# Altium schematic sheet MAIN.SchDoc(MAIN)
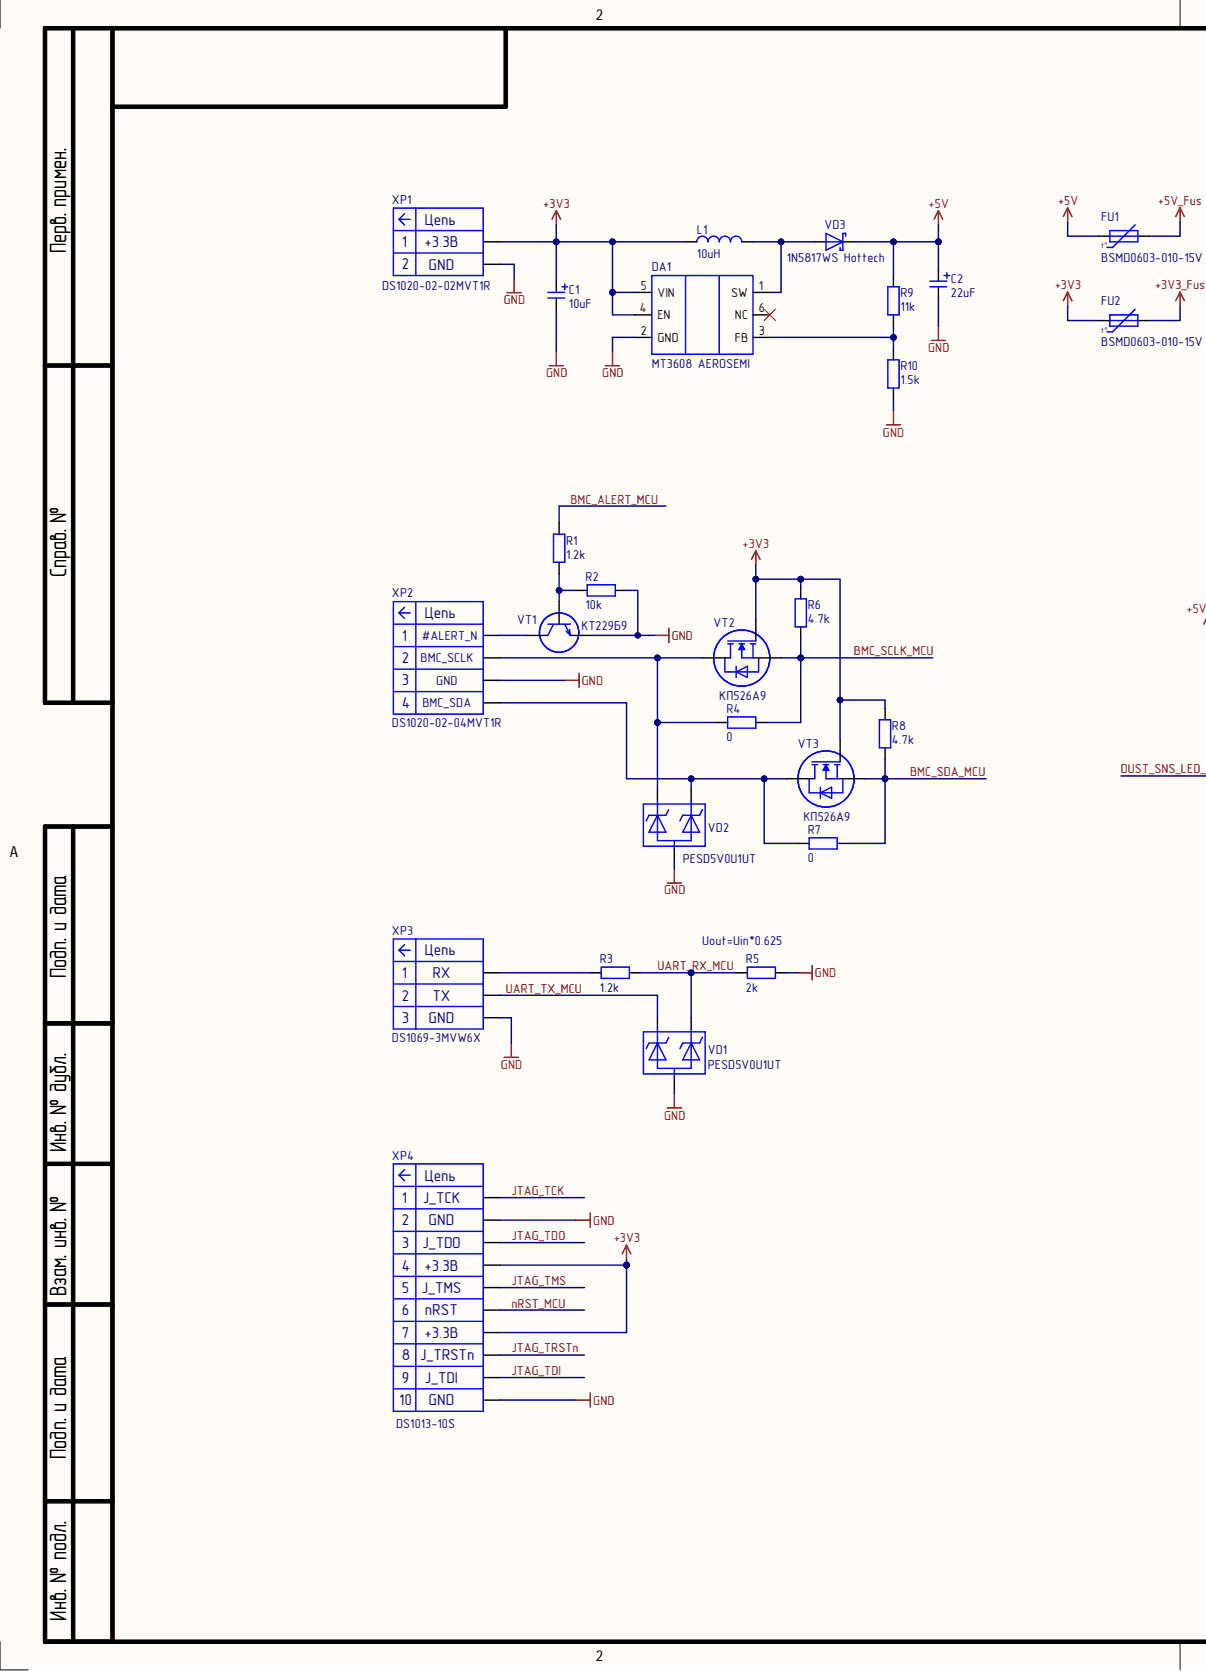
[diagram p0: MAIN.SchDoc, left]
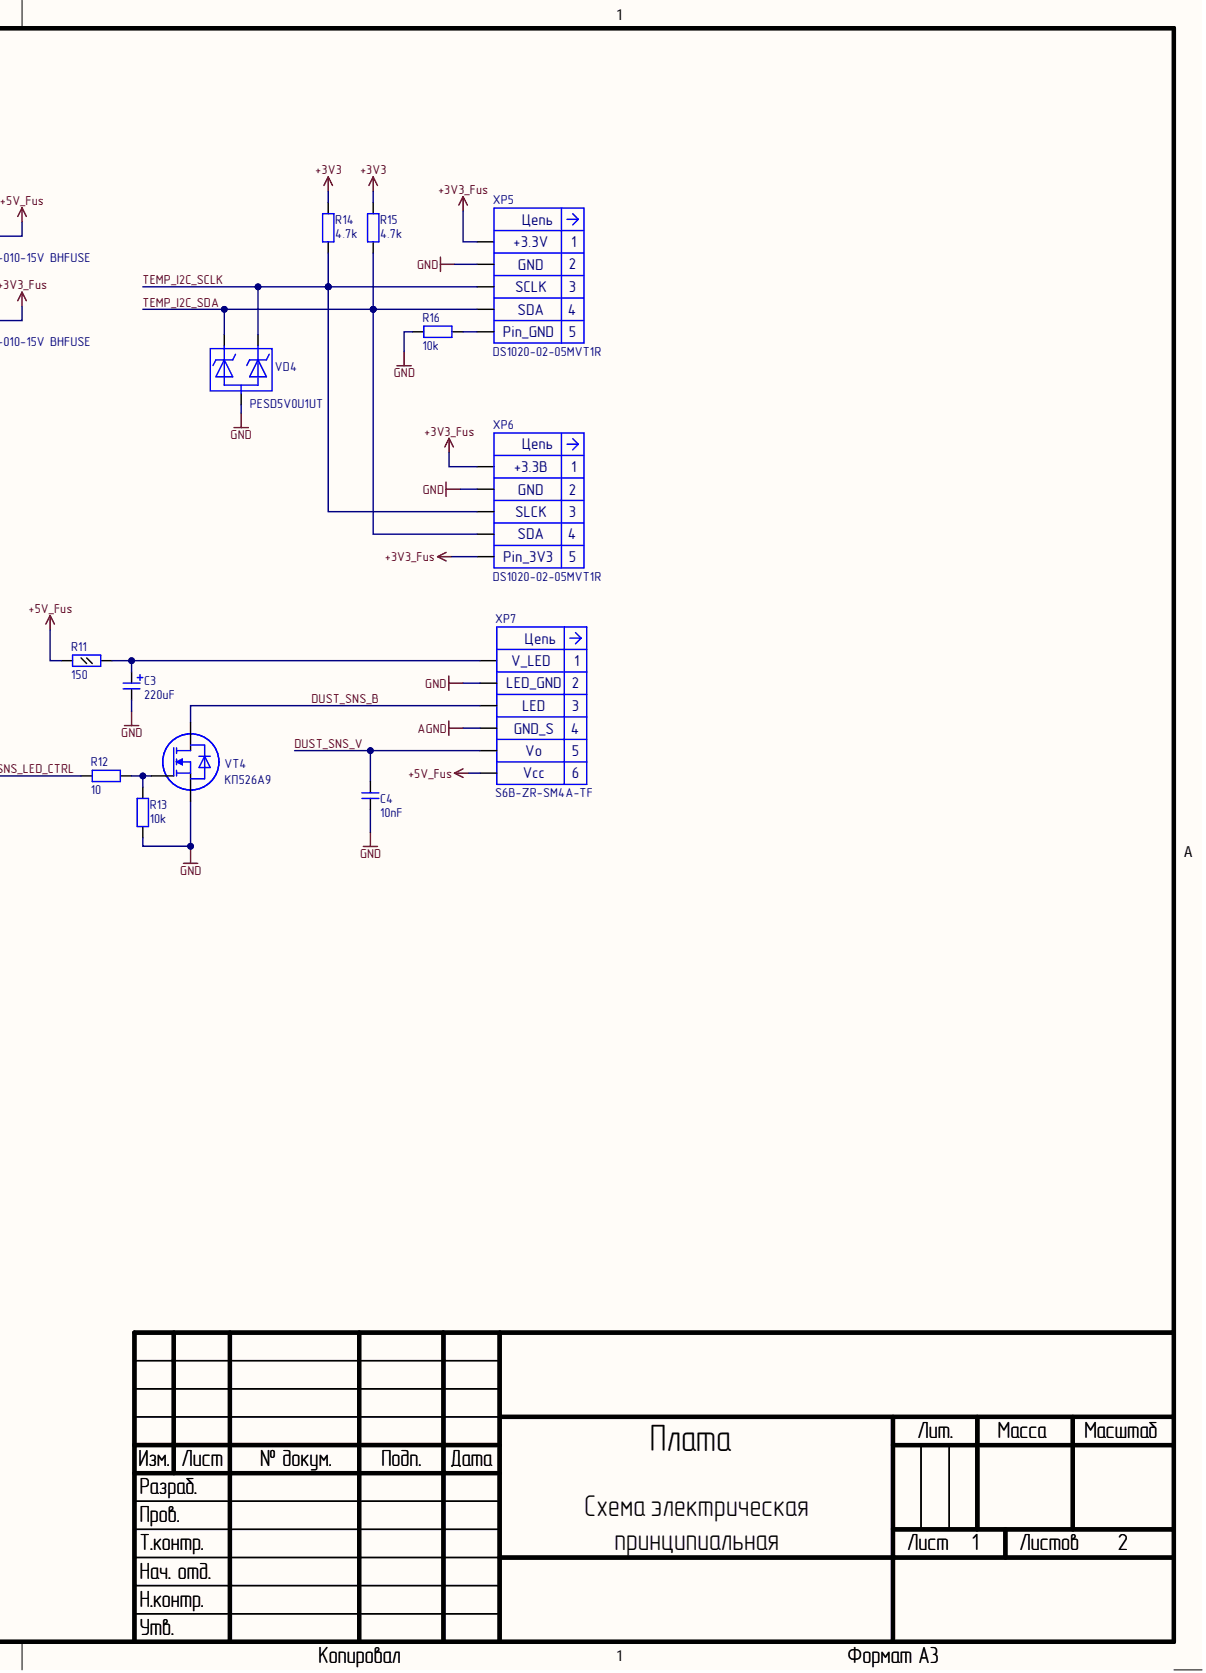
[diagram p1: MAIN.SchDoc, right]

## Parts
C1  10uF  [CAP ALSMD ELEC 4.3MM H5.4MM]
C2  22uF  [CAP ALSMD ELEC 4.3MM H5.4MM]
C3  220uF  [CAP ALSMD ELEC 6.6MM H7.7MM]
C4  10nF  [CAP 0603_1608]
DA1  MT3608 AEROSEMI  Manufacturer: AEROSEMI  Name: MT3608  [TI SOT-23-6 DBV]
FU1  BSMD0603-010-15V BHFUSE  Name: BSMD0603-010-15V  [FUSE 0603_1608 0.8MM]
FU2  BSMD0603-010-15V BHFUSE  Name: BSMD0603-010-15V  [FUSE 0603_1608 0.8MM]
L1  10uH  [MURATA LQH32CN]
R1  1.2k  [RES 0603_1608]
R2  10k  [RES 0603_1608]
R3  1.2k  [RES 0603_1608]
R4  0  [RES 0603_1608]
R5  2k  [RES 0603_1608]
R6  4.7k  [RES 0603_1608]
R7  0  [RES 0603_1608]
R8  4.7k  [RES 0603_1608]
R9  11k  [RES 0603_1608]
R10  1.5k  [RES 0603_1608]
R11  150  [RES 0805_2012]
R12  10  [RES 0603_1608]
R13  10k  [RES 0603_1608]
R14  4.7k  [RES 0603_1608]
R15  4.7k  [RES 0603_1608]
R16  10k  [RES 0603_1608]
VD1  PESD5V0U1UT  [SOT-23-3 N]
VD2  PESD5V0U1UT  [SOT-23-3 N]
VD3  1N5817WS Hottech  Manufacturer: Hottech  Name: 1N5817WS  [MCC SOD-323]
VD4  PESD5V0U1UT  [SOT-23-3 N]
VT1  КТ229Б9  [SOT-23-3 N]
VT2  КП526А9  [SOT-23-3 N]
VT3  КП526А9  [SOT-23-3 N]
VT4  КП526А9  [SOT-23-3 N]
XP1  DS1020-02-02MVT1R  Net_1: +3.3В  Net_2: GND  [DS1020-02-02MVT1R]
XP2  DS1020-02-04MVT1R  Net_1: #ALERT_N  Net_2: BMC_SCLK  Net_3: GND  Net_4: BMC_SDA  [DS1020-02-04MVT1R]
XP3  DS1069-3MVW6X  Net_1: RX  Net_2: TX  Net_3: GND  [CONNFLY_DS1069-3M]
XP4  DS1013-10S  Net_1: J_TCK  Net_2: GND  Net_3: J_TDO  Net_4: +3.3В  Net_5: J_TMS  Net_6: nRST  Net_8: J_TRSTn  Net_9: J_TDI  [DS1013-10S]
XP5  DS1020-02-05MVT1R  Net_1: +3.3V  Net_2: GND  Net_3: SCLK  Net_4: SDA  Net_5: Pin_GND  [DS1020-02-05MVT1R]
XP6  DS1020-02-05MVT1R  Net_1: +3.3В  Net_2: GND  Net_3: SLCK  Net_4: SDA  Net_5: Pin_3V3  [DS1020-02-05MVT1R]
XP7  S6B-ZR-SM4A-TF  Net_1: V_LED  Net_2: LED_GND  Net_3: LED  Net_4: GND_S  Net_5: Vo  Net_6: Vcc  [JST S6B-ZR-SM4A-TF]

## Nets
+3V3: C1.1 DA1.4(EN) DA1.5(VIN) FU2.1 L1.1 R6.2 R8.2 R14.2 R15.2 VT2.1 VT3.1 XP1.1 XP4.4(+3.3В) XP4.7(+3.3В)
+3V3_Fus: FU2.2 XP5.1(+3.3V) XP6.1(+3.3В) XP6.5(Pin_3V3)
+5V: C2.1 FU1.1 R9.2 VD3.2
+5V_Fus: FU1.2 R11.1 XP7.6(Vcc)
AGND: XP7.4(GND_S)
GND: C1.2 C2.2 C3.2 C4.1 DA1.2(GND) R2.1 R5.2 R10.1 R13.1 R16.2 VD1.3 VD2.3 VD4.3 VT1.2 VT4.3 XP1.2 XP2.3 XP3.3 XP4.2 XP4.10 XP5.2(GND) XP6.2(GND) XP7.2(LED_GND)
BMC_ALERT_MCU: R1.2
BMC_SCLK_MCU: R4.1 R6.1 VT2.3
BMC_SDA_MCU: R7.1 R8.1 VT3.3
DUST_SNS_B: VT4.2 XP7.3(LED)
DUST_SNS_LED_CTRL: R12.1
DUST_SNS_V: C4.2 XP7.5(Vo)
JTAG_TCK: XP4.1(J_TCK)
JTAG_TDI: XP4.9(J_TDI)
JTAG_TDO: XP4.3(J_TDO)
JTAG_TMS: XP4.5(J_TMS)
JTAG_TRSTn: XP4.8(J_TRSTn)
nRST_MCU: XP4.6(nRST)
TEMP_I2C_SCLK: R14.1 VD4.2 XP5.3(SCLK) XP6.3(SLCK)
TEMP_I2C_SDA: R15.1 VD4.1 XP5.4(SDA) XP6.4(SDA)
UART_RX_MCU: R3.2 R5.1 VD1.2
UART_TX_MCU: VD1.1 XP3.2
NetC3_1: C3.1 R11.2 XP7.1(V_LED)
NetDA1_1: DA1.1(SW) L1.2 VD3.1
NetDA1_3: DA1.3(FB) R9.1 R10.2
NetR1_1: R1.1 R2.2 VT1.1
NetR3_1: R3.1 XP3.1
NetR4_2: R4.2 VD2.1 VT2.2 XP2.2(BMC_SCLK)
NetR7_2: R7.2 VD2.2 VT3.2 XP2.4(BMC_SDA)
NetR12_2: R12.2 R13.2 VT4.1
NetR16_1: R16.1 XP5.5(Pin_GND)
NetVT1_3: VT1.3 XP2.1(#ALERT_N)

Not connected: DA1 6(NC)

## Library data of the parts
C1 = К50-97 – 25В – 10мкФ (±20) % – ЕВАЯ.673541.054ТУ: Конденсатор К50-97 – 25В – 10мкФ (±20) % – ЕВАЯ.673541.054ТУ; Manufacturer: АО Элеконд; Database Table Name: capacitors.csv; Dielectric type: Electrolytic; Group: Конденсаторы полярные; Tolerance: ± 20%; Type: Конденсатор электролитический; Voltage: 25V; Component Kind: Standard; Country: Россия; HelpURL: https://elecond.ru/pdf/k50-97.pdf; Library Name: ComponentsDb.DbLib; Manufacturer Part Number: К50-97 – 25В – 10мкФ (±20) % – ЕВАЯ.673541.054ТУ; Name: К50-97 – 25В – 10мкФ (±20) % – ЕВАЯ.673541.054ТУ; Package: SMD D4x5.4; Value: 10uF
C2 = К50-97 – 16В – 22мкФ (±20) % – ЕВАЯ.673541.054ТУ: Конденсатор К50-97 – 16В – 22мкФ (±20) % – ЕВАЯ.673541.054ТУ; Manufacturer: АО Элеконд; Database Table Name: capacitors.csv; Dielectric type: Electrolytic; Group: Конденсаторы полярные; Tolerance: ± 20%; Type: Конденсатор электролитический; Voltage: 16V; Component Kind: Standard; Country: Россия; HelpURL: https://elecond.ru/pdf/k50-97.pdf; Library Name: ComponentsDb.DbLib; Manufacturer Part Number: К50-97 – 16В – 22мкФ (±20) % – ЕВАЯ.673541.054ТУ; Name: К50-97 – 16В – 22мкФ (±20) % – ЕВАЯ.673541.054ТУ; Package: SMD D4x5.4; Value: 22uF
C3 = К50-97 – 16В – 220мкФ (±20) % – ЕВАЯ.673541.054ТУ: Конденсатор К50-97 – 16В – 220мкФ (±20) % – ЕВАЯ.673541.054ТУ; Manufacturer: АО Элеконд; Database Table Name: capacitors.csv; Dielectric type: Electrolytic; Group: Конденсаторы полярные; Tolerance: ± 20%; Type: Конденсатор электролитический; Voltage: 16V; Component Kind: Standard; Country: Россия; HelpURL: https://elecond.ru/pdf/k50-97.pdf; Library Name: ComponentsDb.DbLib; Manufacturer Part Number: К50-97 – 16В – 220мкФ (±20) % – ЕВАЯ.673541.054ТУ; Name: К50-97 – 16В – 220мкФ (±20) % – ЕВАЯ.673541.054ТУ; Package: SMD D6.3x7.7; Value: 220uF
C4 = GRM188R71E103K: Конденсатор 0603-X7R-25В-10мкФ ± 10%; Manufacturer: Murata Electronics; Database Table Name: capacitors.csv; Dielectric type: X7R; Group: Конденсаторы; Type: Конденсатор керамический; Voltage: 25V; Component Kind: Standard; Country: Япония; Library Name: ComponentsDb.DbLib; Manufacturer Part Number: GRM188R71E103K; Name: GRM188R71E103K; Package: SMD0603; Tolerance: ± 10%; Value: 10nF
DA1 = MT3608 AEROSEMI: Микросхема MT3608; Database Table Name: regulator_ics.csv; Group: Микросхемы; Input Voltage: 2V to 24V; Max Temperature: 150°С; Min Temperature: -40°С; Output Voltage: Up to 28V; Component Kind: Standard; Country: Китай; Library Name: ComponentsDb.DbLib; Manufacturer Part Number: MT3608 AEROSEMI; Package: SOT23-6; Type: Импульсный повышающий преобразователь
FU1 FU2 = BSMD0603-010-15V BHFUSE: Предохранитель самовосстанавливающийся BSMD0603-010-15V; Database Table Name: fuses.csv; Group: Предохранители; I hold: 0.1A; I trip: 0.3A; V: 15V; Component Kind: Standard; Country: Китай; HelpURL: https://static.chipdip.ru/lib/966/DOC042966016.pdf; Library Name: ComponentsDb.DbLib; Manufacturer: BHFUSE; Manufacturer Part Number: BSMD0603-010-15V BHFUSE; Package: SMD0603; Type: Предохранитель самовосстанавливающийся
L1 = LQH32CN100K33L: Индуктивность 1210-0,45A-10мкГ ± 10%; Manufacturer: Murata Electronics; Current: 450mA; Database Table Name: inductors.csv; Group: Индуктивности; Rdc: 0.3Ohm; SRfreq: 26MHz; Component Kind: Standard; Country: Япония; Library Name: ComponentsDb.DbLib; Manufacturer Part Number: LQH32CN100K33L; Name: LQH32CN100K33L; Package: SMD1206; Tolerance: ± 10%; Type: Индуктивности; Value: 10uH
R1 R3 = Резистор Р1-12 - 0,1 - 1,2кОм - ±1 % - У - "А" АЛЯР.434110.005 ТУ: Manufacturer: АО «НПО «ЭРКОН»; Database Table Name: resistors.csv; Group: Резисторы; Power: 0.1W; Tolerance: ± 1%; Voltage: 50V; Component Kind: Standard; Country: Россия; Description: Резистор Р1-12 - 0,1 - 1,2кОм - ±1 % - У - "А" АЛЯР.434110.005 ТУ; HelpURL: https://www.erkon-nn.ru/upload/model_library/R1-12/r1-12_5.pdf; Library Name: ComponentsDb.DbLib; Manufacturer Part Number: Резистор Р1-12 - 0,1 - 1,2кОм - ±1 % - У - "А" АЛЯР.434110.005 ТУ; Name: Р1-12 - 0,1 - 1,2кОм - ±1 % - У - "А" АЛЯР.434110.005 ТУ; Package: SMD0603; Type: Резистор; Value: 1.2k
R2 R13 R16 = Резистор Р1-12 - 0,1 - 10кОм - ±1 % - У - "А" АЛЯР.434110.005 ТУ: Manufacturer: АО «НПО «ЭРКОН»; Database Table Name: resistors.csv; Group: Резисторы; Power: 0.1W; Tolerance: ± 1%; Voltage: 50V; Component Kind: Standard; Country: Россия; Description: Резистор Р1-12 - 0,1 - 10кОм - ±1 % - У - "А" АЛЯР.434110.005 ТУ; HelpURL: https://www.erkon-nn.ru/upload/model_library/R1-12/r1-12_5.pdf; Library Name: ComponentsDb.DbLib; Manufacturer Part Number: Резистор Р1-12 - 0,1 - 10кОм - ±1 % - У - "А" АЛЯР.434110.005 ТУ; Name: Р1-12 - 0,1 - 10кОм - ±1 % - У - "А" АЛЯР.434110.005 ТУ; Package: SMD0603; Type: Резистор; Value: 10k
R4 R7 = Резистор Р1-12М - 0603 - 0 - А - М - АБШК.434110.023 ТУ: Manufacturer: АО «НПО «ЭРКОН»; Database Table Name: resistors.csv; Group: Резисторы; Component Kind: Standard; Country: Россия; Description: Резистор Р1-12М - 0603 - 0 - А - М - АБШК.434110.023 ТУ; HelpURL: https://www.erkon-nn.ru/upload/model_library/R1-12/r1-12_5.pdf; Library Name: ComponentsDb.DbLib; Manufacturer Part Number: Резистор Р1-12М - 0603 - 0 - А - М - АБШК.434110.023 ТУ; Name: Р1-12М - 0603 - 0 - А - М - АБШК.434110.023 ТУ; Package: SMD0603; Type: Резистор; Value: 0
R5 = Резистор Р1-12 - 0,1 - 2кОм - ±1 % - У - "А" АЛЯР.434110.005 ТУ: Manufacturer: АО «НПО «ЭРКОН»; Database Table Name: resistors.csv; Group: Резисторы; Power: 0.1W; Tolerance: ± 1%; Voltage: 50V; Component Kind: Standard; Country: Россия; Description: Резистор Р1-12 - 0,1 - 2кОм - ±1 % - У - "А" АЛЯР.434110.005 ТУ; HelpURL: https://www.erkon-nn.ru/upload/model_library/R1-12/r1-12_5.pdf; Library Name: ComponentsDb.DbLib; Manufacturer Part Number: Резистор Р1-12 - 0,1 - 2кОм - ±1 % - У - "А" АЛЯР.434110.005 ТУ; Name: Р1-12 - 0,1 - 2кОм - ±1 % - У - "А" АЛЯР.434110.005 ТУ; Package: SMD0603; Type: Резистор; Value: 2k
R6 R8 R14 R15 = Резистор Р1-12 - 0,1 - 4,7кОм - ±1 % - У - "А" АЛЯР.434110.005 ТУ: Manufacturer: АО «НПО «ЭРКОН»; Database Table Name: resistors.csv; Group: Резисторы; Power: 0.1W; Tolerance: ± 1%; Voltage: 50V; Component Kind: Standard; Country: Россия; Description: Резистор Р1-12 - 0,1 - 4,7кОм - ±1 % - У - "А" АЛЯР.434110.005 ТУ; HelpURL: https://www.erkon-nn.ru/upload/model_library/R1-12/r1-12_5.pdf; Library Name: ComponentsDb.DbLib; Manufacturer Part Number: Резистор Р1-12 - 0,1 - 4,7кОм - ±1 % - У - "А" АЛЯР.434110.005 ТУ; Name: Р1-12 - 0,1 - 4,7кОм - ±1 % - У - "А" АЛЯР.434110.005 ТУ; Package: SMD0603; Type: Резистор; Value: 4.7k
R9 = Резистор Р1-12 - 0,1 - 11кОм - ±1 % - У - "А" АЛЯР.434110.005 ТУ: Manufacturer: АО «НПО «ЭРКОН»; Database Table Name: resistors.csv; Group: Резисторы; Power: 0.1W; Tolerance: ± 1%; Voltage: 50V; Component Kind: Standard; Country: Россия; Description: Резистор Р1-12 - 0,1 - 11кОм - ±1 % - У - "А" АЛЯР.434110.005 ТУ; HelpURL: https://www.erkon-nn.ru/upload/model_library/R1-12/r1-12_5.pdf; Library Name: ComponentsDb.DbLib; Manufacturer Part Number: Резистор Р1-12 - 0,1 - 11кОм - ±1 % - У - "А" АЛЯР.434110.005 ТУ; Name: Р1-12 - 0,1 - 11кОм - ±1 % - У - "А" АЛЯР.434110.005 ТУ; Package: SMD0603; Type: Резистор; Value: 11k
R10 = Резистор Р1-12 - 0,1 - 1,5кОм - ±1 % - У - "А" АЛЯР.434110.005 ТУ: Manufacturer: АО «НПО «ЭРКОН»; Database Table Name: resistors.csv; Group: Резисторы; Power: 0.1W; Tolerance: ± 1%; Voltage: 50V; Component Kind: Standard; Country: Россия; Description: Резистор Р1-12 - 0,1 - 1,5кОм - ±1 % - У - "А" АЛЯР.434110.005 ТУ; HelpURL: https://www.erkon-nn.ru/upload/model_library/R1-12/r1-12_5.pdf; Library Name: ComponentsDb.DbLib; Manufacturer Part Number: Резистор Р1-12 - 0,1 - 1,5кОм - ±1 % - У - "А" АЛЯР.434110.005 ТУ; Name: Р1-12 - 0,1 - 1,5кОм - ±1 % - У - "А" АЛЯР.434110.005 ТУ; Package: SMD0603; Type: Резистор; Value: 1.5k
R11 = Резистор Р1-12 - 0,125 - 150Ом - ±1 % - У - "А" АЛЯР.434110.005 ТУ: Manufacturer: АО «НПО «ЭРКОН»; Database Table Name: resistors.csv; Group: Резисторы; Power: 0.125W; Tolerance: ± 1%; Voltage: 150V; Component Kind: Standard; Country: Россия; Description: Резистор Р1-12 - 0,125 - 150Ом - ±1 % - У - "А" АЛЯР.434110.005 ТУ; HelpURL: https://www.erkon-nn.ru/upload/model_library/R1-12/r1-12_5.pdf; Library Name: ComponentsDb.DbLib; Manufacturer Part Number: Резистор Р1-12 - 0,125 - 150Ом - ±1 % - У - "А" АЛЯР.434110.005 ТУ; Name: Р1-12 - 0,125 - 150Ом - ±1 % - У - "А" АЛЯР.434110.005 ТУ; Package: SMD0805; Type: Резистор; Value: 150
R12 = Резистор Р1-12 - 0,1 - 10Ом - ±1 % - У - "А" АЛЯР.434110.005 ТУ: Manufacturer: АО «НПО «ЭРКОН»; Database Table Name: resistors.csv; Group: Резисторы; Power: 0.1W; Tolerance: ± 1%; Voltage: 50V; Component Kind: Standard; Country: Россия; Description: Резистор Р1-12 - 0,1 - 10Ом - ±1 % - У - "А" АЛЯР.434110.005 ТУ; HelpURL: https://www.erkon-nn.ru/upload/model_library/R1-12/r1-12_5.pdf; Library Name: ComponentsDb.DbLib; Manufacturer Part Number: Резистор Р1-12 - 0,1 - 10Ом - ±1 % - У - "А" АЛЯР.434110.005 ТУ; Name: Р1-12 - 0,1 - 10Ом - ±1 % - У - "А" АЛЯР.434110.005 ТУ; Package: SMD0603; Type: Резистор; Value: 10
VD1 VD2 VD4 = PESD5V0U1UT: Диод ESD PESD5V0U1UT; Manufacturer: UTD Semiconductor; Database Table Name: diodes.csv; Group: Диоды; Type: Сборка ESD диодов; Vrwm: 5V; Component Kind: Standard; Country: Китай; Library Name: ComponentsDb.DbLib; Manufacturer Part Number: PESD5V0U1UT; Name: PESD5V0U1UT; Package: SOT23-132
VD3 = 1N5817WS Hottech: Диод Шоттки 1N5817WS; Database Table Name: diodes.csv; Group: Диоды; Max If: 1A; Max Vr: 20V; Component Kind: Standard; Country: Китай; Library Name: ComponentsDb.DbLib; Manufacturer Part Number: 1N5817WS Hottech; Package: SOD-323; Type: Диод Шоттки
VT1 = КТ228Б9 АДКБ.432140.555 ТУ: Транзистор КТ228Б9 АДКБ.432140.555 ТУ; Manufacturer: Кремний Эл; Database Table Name: transistors.csv; Group: Транзисторы; h21: 100-250; Id/Ic: 500mA; Struct: NPN; Type: Транзистор биполярный; Vds/Vce: 45V; Component Kind: Standard; Country: Россия; HelpURL: https://group-kremny.ru/upload/iblock/c8e/c8e3c704764e7e34f4ed87f6430e15b8.pdf; Library Name: ComponentsDb.DbLib; Manufacturer Part Number: КТ228Б9 АДКБ.432140.555 ТУ; Name: КТ229Б9; Package: SOT-23-132
VT2-VT4 = КП526А9 АДКБ.432140.549 ТУ: Транзистор КП546А9 АДКБ.432140.549; Manufacturer: Кремний Эл; Database Table Name: transistors.csv; Group: Транзисторы; Id/Ic: 2; Struct: N-MOSFET; Type: Транзистор полевой; Vds/Vce: 30V; Vth: 1,45; Component Kind: Standard; Country: Россия; Library Name: ComponentsDb.DbLib; Manufacturer Part Number: КП526А9 АДКБ.432140.549 ТУ; Name: КП526А9; Package: SOT-23-132
XP1 = DS1020-02-02MVT1R: Соединитель DS1020-02-02MVT1R; Manufacturer: Connfly; Database Table Name: connectors.csv; Group: Соединители; Max Temperature: 105°С; Min Temperature: -40°С; Nominal Voltage: 250V; Pins number: 2; Rows: 1; Component Kind: Standard; Country: Китай; Library Name: ComponentsDb.DbLib; Manufacturer Part Number: DS1020-02-02MVT1R; Name: DS1020-02-02MVT1R; Type: Вилка угловая
XP2 = DS1020-02-04MVT1R: Соединитель DS1020-02-04MVT1R; Manufacturer: Connfly; Database Table Name: connectors.csv; Group: Соединители; Max Temperature: 105°С; Min Temperature: -40°С; Nominal Voltage: 250V; Pins number: 4; Rows: 1; Component Kind: Standard; Country: Китай; Library Name: ComponentsDb.DbLib; Manufacturer Part Number: DS1020-02-04MVT1R; Name: DS1020-02-04MVT1R; Type: Вилка угловая
XP3 = DS1069-3MVW6X: Соединитель DS1069-3MVW6X; Manufacturer: Connfly; Database Table Name: connectors.csv; Group: Соединители; Max Temperature: 85°С; Min Temperature: -25°С; Nominal current: 3A; Nominal Voltage: 250V; Pins number: 3; Rows: 1; Component Kind: Standard; Country: Китай; Library Name: ComponentsDb.DbLib; Manufacturer Part Number: DS1069-3MVW6X; Name: DS1069-3MVW6X; Type: Вилка прямая
XP4 = DS1013-10S: Соединитель DS1013-10S; Manufacturer: Connfly; Database Table Name: connectors.csv; Group: Соединители; Max Temperature: 105°С; Min Temperature: -40°С; Nominal current: 1A; Nominal Voltage: 500V; Pins number: 10; Rows: 2; Component Kind: Standard; Country: Китай; Library Name: ComponentsDb.DbLib; Manufacturer Part Number: DS1013-10S; Name: DS1013-10S; Net_7: +3.3В; Net_10: GND; Type: Вилка прямая
XP5 XP6 = DS1020-02-05MVT1R: Соединитель DS1020-02-05MVT1R; Manufacturer: Connfly; Database Table Name: connectors.csv; Group: Соединители; Max Temperature: 105°С; Min Temperature: -40°С; Nominal Voltage: 250V; Pins number: 5; Rows: 1; Component Kind: Standard; Country: Китай; Library Name: ComponentsDb.DbLib; Manufacturer Part Number: DS1020-02-05MVT1R; Name: DS1020-02-05MVT1R; Type: Вилка угловая
XP7 = S6B-ZR-SM4A-TF: Соединитель S6B-ZR-SM4A-TF; Manufacturer: JST; Database Table Name: connectors.csv; Group: Соединители; Max Temperature: 85°С; Min Temperature: -25°С; Nominal current: 1A; Nominal Voltage: 50V; Pins number: 6; Rows: 1; Component Kind: Standard; Country: Китай; Library Name: ComponentsDb.DbLib; Manufacturer Part Number: S6B-ZR-SM4A-TF; Name: S6B-ZR-SM4A-TF; Type: Вилка угловая
